# Altium schematic sheet MSXIV_BatteryModuleConnectorBoard.SchDoc(MSXIV_BatteryModuleConnectorBoard)
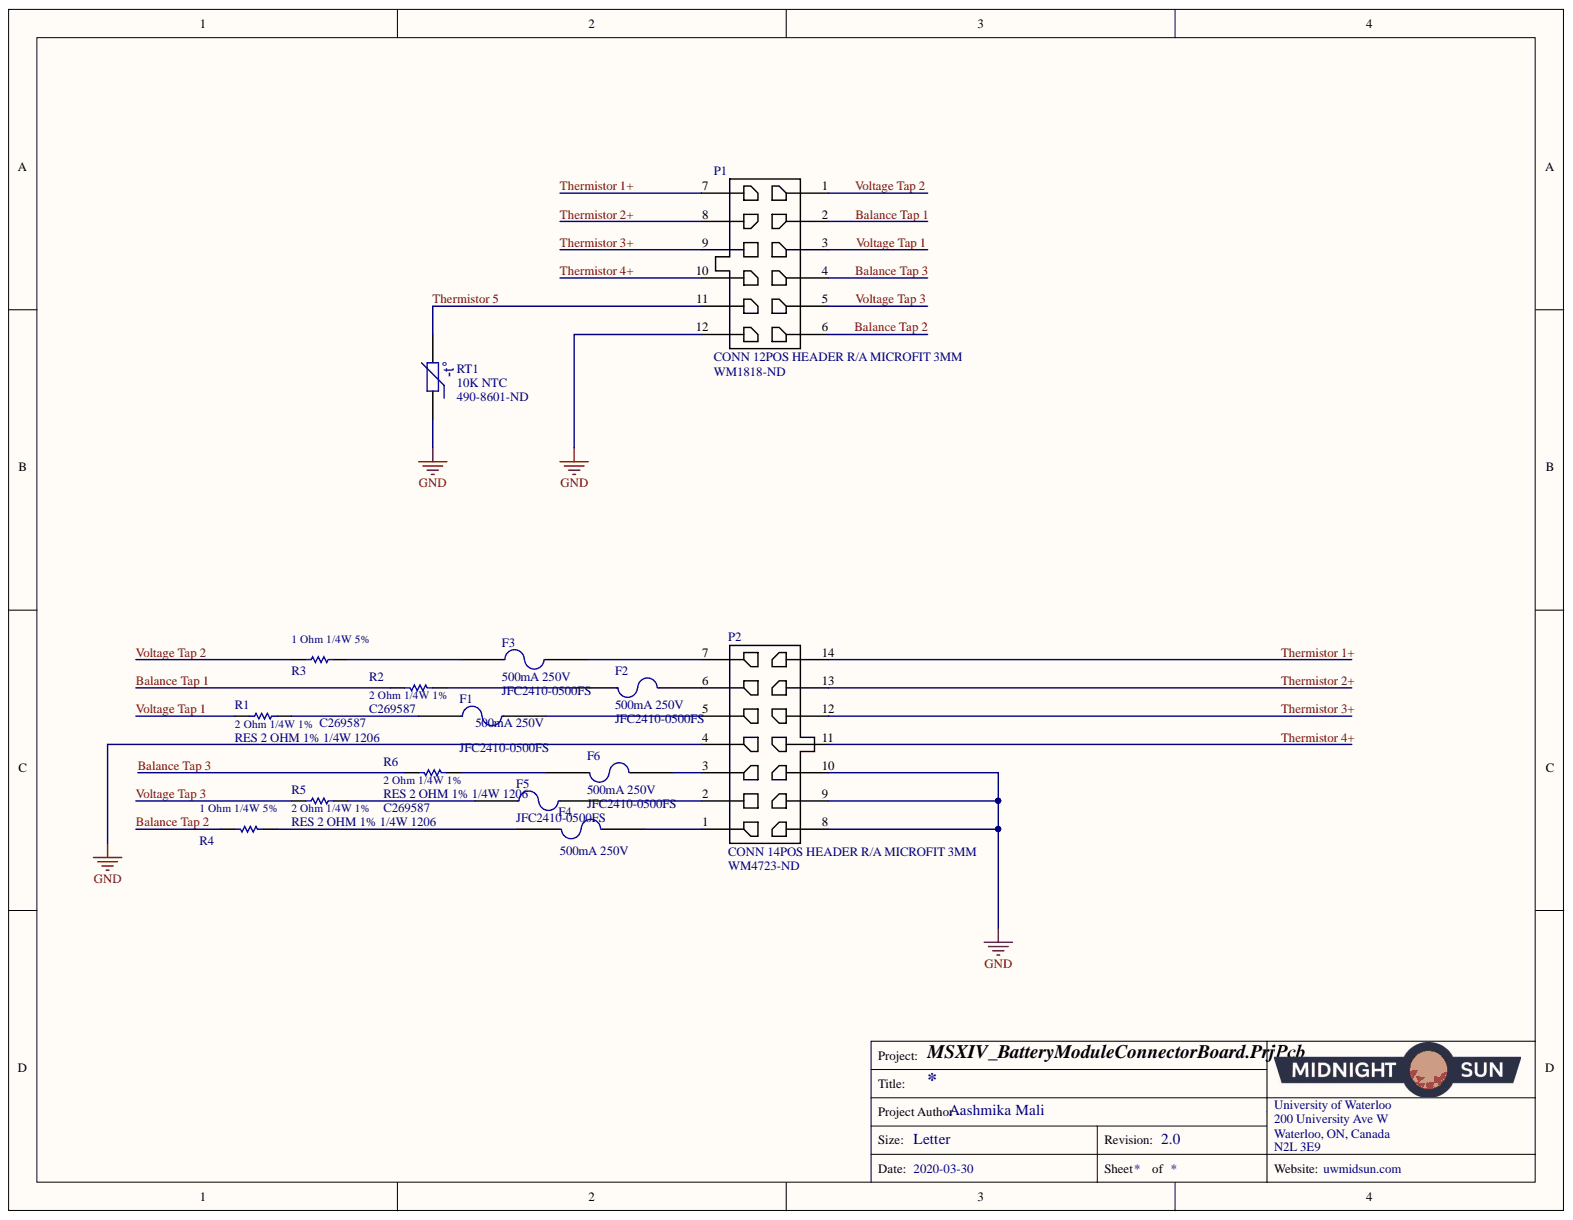
[diagram p0: MSXIV_BatteryModuleConnectorBoard.SchDoc]

## Parts
F1  500mA 250V  [FUSE JFC2410-0500FS]
F2  500mA 250V  Manufacturer Part Number 1: JFC2410-0500FS  [FUSE JFC2410-0500FS]
F3  500mA 250V  [FUSE JFC2410-0500FS]
F4  500mA 250V  Manufacturer Part Number 1: JFC2410-0500FS  [FUSE JFC2410-0500FS]
F5  500mA 250V  Manufacturer Part Number 1: JFC2410-0500FS  [FUSE JFC2410-0500FS]
F6  500mA 250V  Manufacturer Part Number 1: JFC2410-0500FS  [FUSE JFC2410-0500FS]
P1  CONN 12POS HEADER R/A MICROFIT 3MM  Supplier Part Number 1: WM1818-ND  [CONN, 12POS 3MM HEADER R/A]
P2  CONN 14POS HEADER R/A MICROFIT 3MM  Supplier Part Number 1: WM4723-ND  [CONN, 14POS 3MM HEADER R/A]
R1  RES 2 OHM 1% 1/4W 1206  Supplier Part Number 1: C269587  [RES 1206]
R2  RES 2 OHM 1% 1/4W 1206  Supplier Part Number 1: C269587  [RES 1206]
R3  RES 1 OHM 5% 1/4W 1206  [RES 1206]  (value not drawn)
R4  RES 1 OHM 5% 1/4W 1206  [RES 1206]  (value not drawn)
R5  RES 2 OHM 1% 1/4W 1206  Supplier Part Number 1: C269587  [RES 1206]
R6  RES 2 OHM 1% 1/4W 1206  Supplier Part Number 1: C269587  Case/Package: 1206  [RES 1206]
RT1  10K NTC  Supplier Part Number 1: 490-8601-ND  [NTC THERMISTOR BEAD]

## Nets
GND: P1.12 P2.4 P2.8 P2.9 P2.10 RT1.1
Balance Tap 1: P1.2 R2.2
Balance Tap 2: P1.6 R4.2
Balance Tap 3: P1.4 R6.2
Thermistor 1+: P1.7 P2.14
Thermistor 2+: P1.8 P2.13
Thermistor 3+: P1.9 P2.12
Thermistor 4+: P1.10 P2.11
Thermistor 5: P1.11 RT1.2
Voltage Tap 1: P1.3 R1.1
Voltage Tap 2: P1.1 R3.1
Voltage Tap 3: P1.5 R5.1
NetF1_1: F1.1 R1.2
NetF1_2: F1.2 P2.5
NetF2_1: F2.1 P2.6
NetF2_2: F2.2 R2.1
NetF3_1: F3.1 R3.2
NetF3_2: F3.2 P2.7
NetF4_1: F4.1 P2.1
NetF4_2: F4.2 R4.1
NetF5_1: F5.1 R5.2
NetF5_2: F5.2 P2.2
NetF6_1: F6.1 P2.3
NetF6_2: F6.2 R6.1

## Library data of the parts
F1 F3 = FUSE 500MA LCSC: 2410 Surface Mount Fuses RoHS; Manufacturer Part Number 1: JFC2410-0500FS; Manufacturer 1: Shenzen JDT Fuse; Supplier Part Number 1: C136360; Supplier 1: LCSC; Pricing 1: 1=0.0591USD; ComponentLink1URL: https://datasheet.lcsc.com/szlcsc/1809211424_Shenzhen-JDT-Fuse-JFC2410-0500FS_C136360.pdf; ComponentLink1Description: Shenzhen JDT Fuse; Component Kind: Standard; Library Name: Schematic Diagrams.SchLib
F2 F4-F6 = FUSE 500MA LCSC: 2410 Surface Mount Fuses RoHS; Manufacturer 1: Shenzen JDT Fuse; Supplier Part Number 1: C136360; Supplier 1: LCSC; Pricing 1: 1=0.0591USD; ComponentLink1URL: https://datasheet.lcsc.com/szlcsc/1809211424_Shenzhen-JDT-Fuse-JFC2410-0500FS_C136360.pdf; ComponentLink1Description: Shenzhen JDT Fuse; Component Kind: Standard; Library Name: Schematic Diagrams.SchLib
P1 = CONN 12POS HEADER R/A MICROFIT 3MM: Manufacturer Part Number 1: 0430451200; Manufacturer 1: Molex; Row Spacing: 0.118inch; Number of Positions: 12; Max Voltage Rating (AC): 250V; Contact Resistance: 10mR; Connector Type: Male Pin; Termination: Solder; Supplier 1: Digi-Key; Stock 1: 4137; RoHS: Yes; Pricing 1: 1=2.73000, 10=2.62200, 100=2.18500, 500=1.85726, 1000=1.58413, 5000=1.42025 (USD); Pitch: 3mm; Packaging: Tray; Orientation: Right Angle; Number of Rows: 2; Number of Contacts: 12; Mount: Through Hole; Min Operating Temperature: -40°C; Max Operating Temperature: 105°C; Length: 22.15mm; Housing Material: Polymer; Housing Color: Black; Flammability Rating: UL94 V-0; Fastening Type: Ramp; Depth: 12.2mm; Contact Plating: Tin; Contact Material: Brass; ComponentLink1URL: https://datasheet.ciiva.com/6814/430450202-sd-6814012.pdf?src-supplier=Digi-Key; ComponentLink1Description: https://datasheet.ciiva.com/6814/430450202-sd-6814012.pdf?src-supplier=Digi-Key; Component Kind: Standard; Color: Black; Library Name: Schematic Diagrams.SchLib
P2 = CONN 14POS HEADER R/A MICROFIT 3MM: Manufacturer Part Number 1: 0430451400; Manufacturer 1: Molex; Row Spacing: 0.118inch; Number of Positions: 14; Max Voltage Rating (AC): 250V; Contact Resistance: 10mR; Connector Type: Male Pin; Termination: Solder; Supplier 1: Digi-Key; Stock 1: 317; RoHS: Yes; Pricing 1: 1=3.70000, 10=3.55300, 100=2.96080, 500=2.51668, 1000=2.14658, 5000=1.92452 (USD); Pitch: 3mm; Packaging: Tray; Orientation: Right Angle; Number of Rows: 2; Number of Contacts: 14; Mount: Through Hole; Min Operating Temperature: -40°C; Max Operating Temperature: 105°C; Length: 25.15mm; Housing Material: Polymer; Housing Color: Black; Flammability Rating: UL94 V-0; Fastening Type: Ramp; Depth: 12.2mm; Contact Plating: Tin; Contact Material: Brass; ComponentLink3URL: https://datasheet.ciiva.com/3933/159470430451400-pcb-headers-3933370.pdf?src-supplier=Arrow; ComponentLink3Description: https://datasheet.ciiva.com/3933/159470430451400-pcb-headers-3933370.pdf?src-supplier=Arrow; ComponentLink2URL: https://datasheet.ciiva.com/6814/430450202-sd-6814012.pdf?src-supplier=Digi-Key; ComponentLink2Description: https://datasheet.ciiva.com/6814/430450202-sd-6814012.pdf?src-supplier=Digi-Key; Component Kind: Standard; Color: Black; Library Name: Schematic Diagrams.SchLib
R1 R2 R5 R6 = RES 2 OHM 1% 1/4W 1206: 2 ±1% 1/4W 1206 Chip Resistor - Surface Mount RoHS; Manufacturer Part Number 1: RMC120621%N; Manufacturer 1: TyoHM; Voltage Rating (DC): 200V; Resistance: 2R; Power Rating: 250mW; Supplier 1: LCSC; RoHS: No; Lead Free: Lead Free; Component Kind: Standard; Category: Chip SMD Resistors; Library Name: Schematic Diagrams.SchLib
  R1 R2 R5: Case/Package: 1206
R3 R4 = RES 1 OHM 5% 1/4W 1206: 1 ±5% 1/4W 1206 Chip Resistor - Surface Mount RoHS; Manufacturer Part Number 1: RMC120615%N; Manufacturer 1: TyoHM; Supplier Part Number 1: C325901; Supplier 1: LCSC; Component Kind: Standard; Library Name: Schematic Diagrams.SchLib
RT1 = NTC THERMISTOR 10K 1% BEAD: NTC Thermistor 10k Bead; Manufacturer Part Number: NXRT15XH103FA1B030; Manufacturer: Murata Electronics North America; Resistance Tolerance: ±1%; B25/100: 3455K; B25/85: 3434K; B25/50: 3380K; Supplier 1: Digi-Key; Stock 1: 25017; RoHS: RoHS Compliant; Resistance in Ohms @ 25°C: 10k; Pricing 1: 1=0.96, 5=0.91, 10=0.792, 25=0.696, 50=0.5784, 100=0.4925, 500=0.42834, 1000=0.3748, 5000=0.33197 (CAD); Part Status: Active; Packaging: Bulk; Package / Case: Bead; Operating Temperature: -40°C ~ 125°C; Mounting Type: Through Hole; Length - Lead Wire: -; ComponentLink1URL: https://www.murata.com/~/media/webrenewal/support/library/catalog/products/thermistor/ntc/r44e.ashx; ComponentLink1Description: https://www.murata.com/~/media/webrenewal/support/library/catalog/products/thermistor/ntc/r44e.ashx; Component Kind: Standard; Category: Sensors, Transducers; B Value Tolerance: ±1%; B25/75: -; B0/50: -; Library Name: Schematic Diagrams.SchLib
